# Altium schematic sheet BC26_OPEN_RS485_RELAY_SIGNAL.SchDoc(BC26_OPEN_RS485_RELAY_SIGNAL)
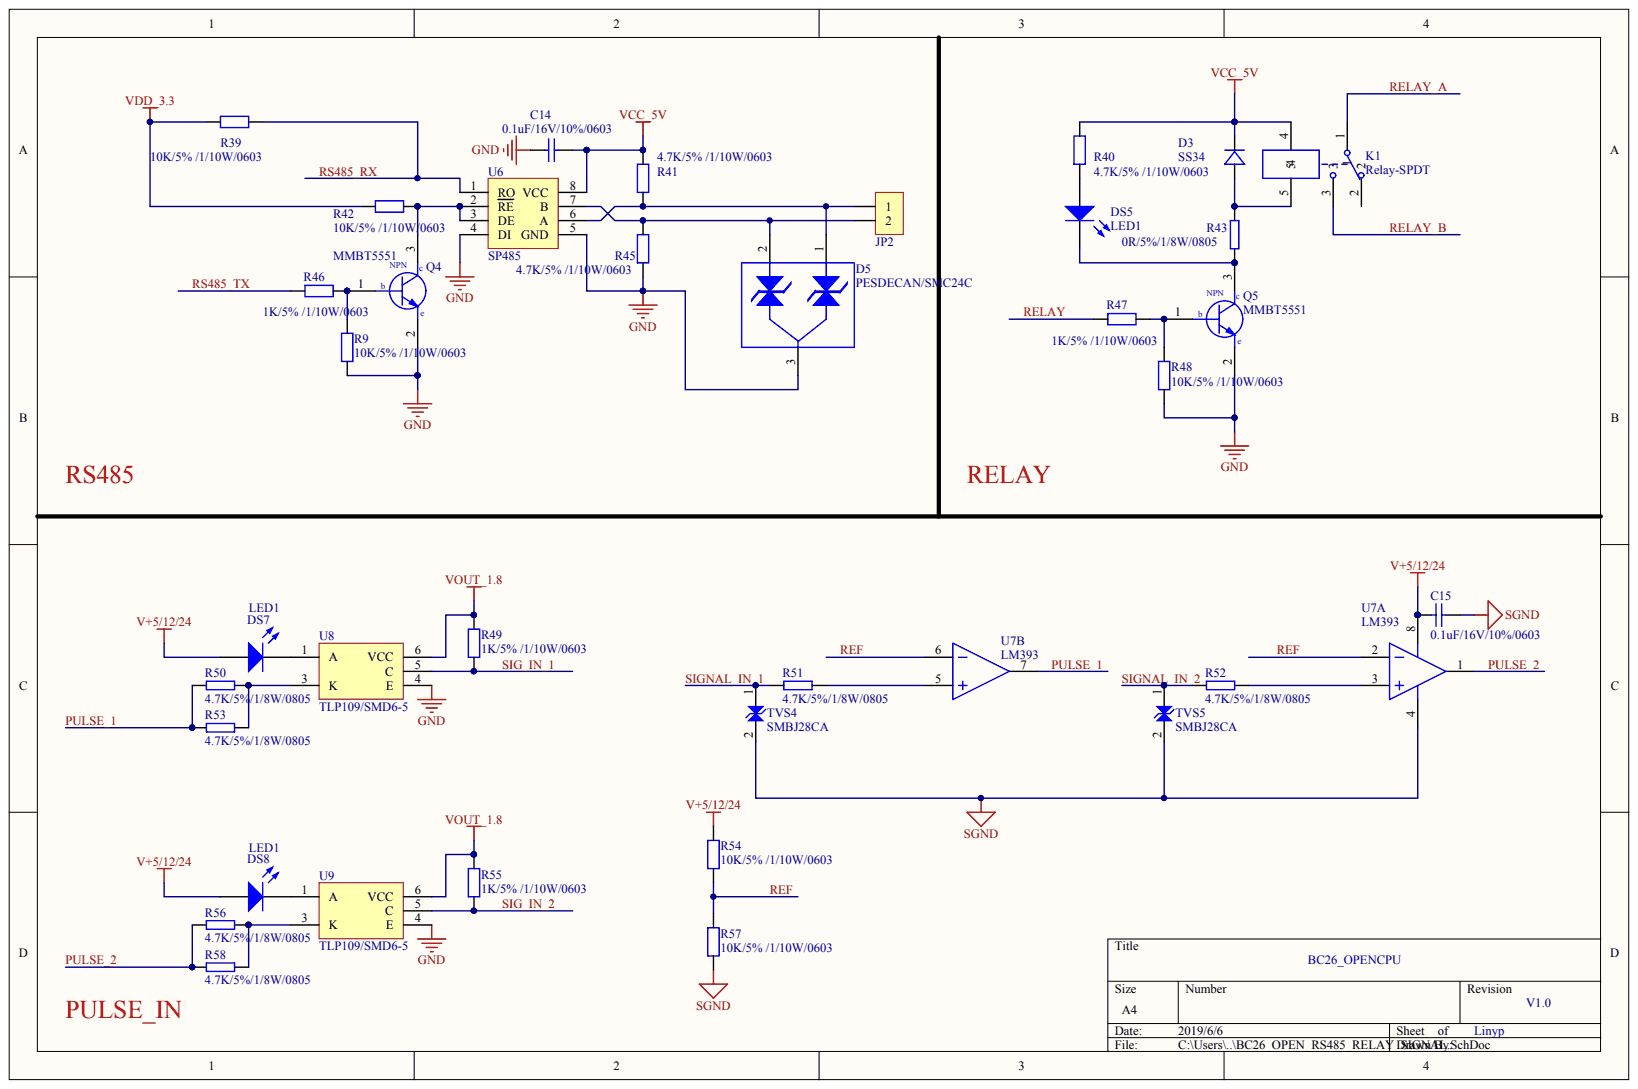
[diagram p0: BC26_OPEN_RS485_RELAY_SIGNAL.SchDoc]

## Parts
C14  0.1uF/16V/10%/0603
C15  0.1uF/16V/10%/0603
D3  SS34
D5  PESDECAN/SMC24C
DS5  LED1
DS7  LED1
DS8  LED1
JP2  HDR-1X2  (value not drawn)
K1  Relay-SPDT
Q4  MMBT5551
Q5  MMBT5551
R9  10K/5% /1/10W/0603
R39  10K/5% /1/10W/0603
R40  4.7K/5% /1/10W/0603
R41  4.7K/5% /1/10W/0603
R42  10K/5% /1/10W/0603
R43  0R/5%/1/8W/0805
R45  4.7K/5% /1/10W/0603
R46  1K/5% /1/10W/0603
R47  1K/5% /1/10W/0603
R48  10K/5% /1/10W/0603
R49  1K/5% /1/10W/0603
R50  4.7K/5%/1/8W/0805
R51  4.7K/5%/1/8W/0805
R52  4.7K/5%/1/8W/0805
R53  4.7K/5%/1/8W/0805
R54  10K/5% /1/10W/0603
R55  1K/5% /1/10W/0603
R56  4.7K/5%/1/8W/0805
R57  10K/5% /1/10W/0603
R58  4.7K/5%/1/8W/0805
TVS4  SMBJ28CA
TVS5  SMBJ28CA
U6  SP485
U7  LM393  (parts A,B)
U8  TLP109/SMD6-5
U9  TLP109/SMD6-5

## Nets
GND: C14.2 D5.3 Q4.2 Q5.2 R9.1 R45.1 R48.1 U6.4(DI) U6.5(GND) U8.4(E) U9.4(E)
SGND: C15.2 R57.1 TVS4.2 TVS5.2 U7.4
V+5/12/24: C15.1 DS7.1 DS8.1 R54.2 U7.8
VCC_5V: C14.1 D3.2 K1.4 R40.2 R41.2 U6.8(VCC)
VDD_3.3: R39.1 R42.2
VOUT_1.8: R49.2 R55.2 U8.6(VCC) U9.6(VCC)
PULSE_1: R50.2 R53.2 U7.7
PULSE_2: R56.2 R58.2 U7.1
REF: R54.1 R57.2 U7.2 U7.6
RELAY: R47.1
RELAY_A: K1.1
RELAY_B: K1.3
RS485_RX: R39.2 U6.1(RO)
RS485_TX: R46.1
SIG_IN_1: R49.1 U8.5(C)
SIG_IN_2: R55.1 U9.5(C)
SIGNAL_IN_1: R51.1 TVS4.1
SIGNAL_IN_2: R52.1 TVS5.1
NetD3_1: D3.1 K1.5 R43.2
NetD5_1: D5.1 JP2.1 R41.1 U6.6(A)
NetD5_2: D5.2 JP2.2 R45.2 U6.7(B)
NetDS5_1: DS5.1 R40.1
NetDS5_2: DS5.2 Q5.3 R43.1
NetDS7_2: DS7.2 U8.1(A)
NetDS8_2: DS8.2 U9.1(A)
NetQ4_1: Q4.1 R9.2 R46.2
NetQ4_3: Q4.3 R42.1 U6.2(RE) U6.3(DE)
NetQ5_1: Q5.1 R47.2 R48.2
NetR50_1: R50.1 R53.1 U8.3(K)
NetR51_2: R51.2 U7.5
NetR52_2: R52.2 U7.3
NetR56_1: R56.1 R58.1 U9.3(K)

Not connected: K1 2

## Library data of the parts
JP2 = (no symbol name): BOM名称: 2P接插件; 版权归属: 源创客; 学习资源: www.ooocke.com
C14 C15 D3 D5 DS5 DS7 DS8 K1 Q4 Q5 R9 R39-R43 R45-R58 TVS4 TVS5 U6-U9 = (no symbol name)
  C14 C15: Published: 8-Jun-2000; LatestRevisionDate: 17-Jul-2002; LatestRevisionNote: Re-released for DXP Platform.; PackageReference: RAD-0.3
  DS5 DS7 DS8: Published: 8-Jun-2000; LatestRevisionDate: 17-Jul-2002; LatestRevisionNote: Re-released for DXP Platform.; PackageDocument: Feb-2002; PackageReference: 3.2X1.6X1.1
  K1: Published: 24-Mar-1999; LatestRevisionDate: 17-Jul-2002; LatestRevisionNote: Re-released for DXP Platform.; PackageReference: MODULE5B
  C14 C15 DS5 DS7 DS8 K1: Publisher: Altium Limited
